# Altium schematic sheet SWITCHING.SchDoc
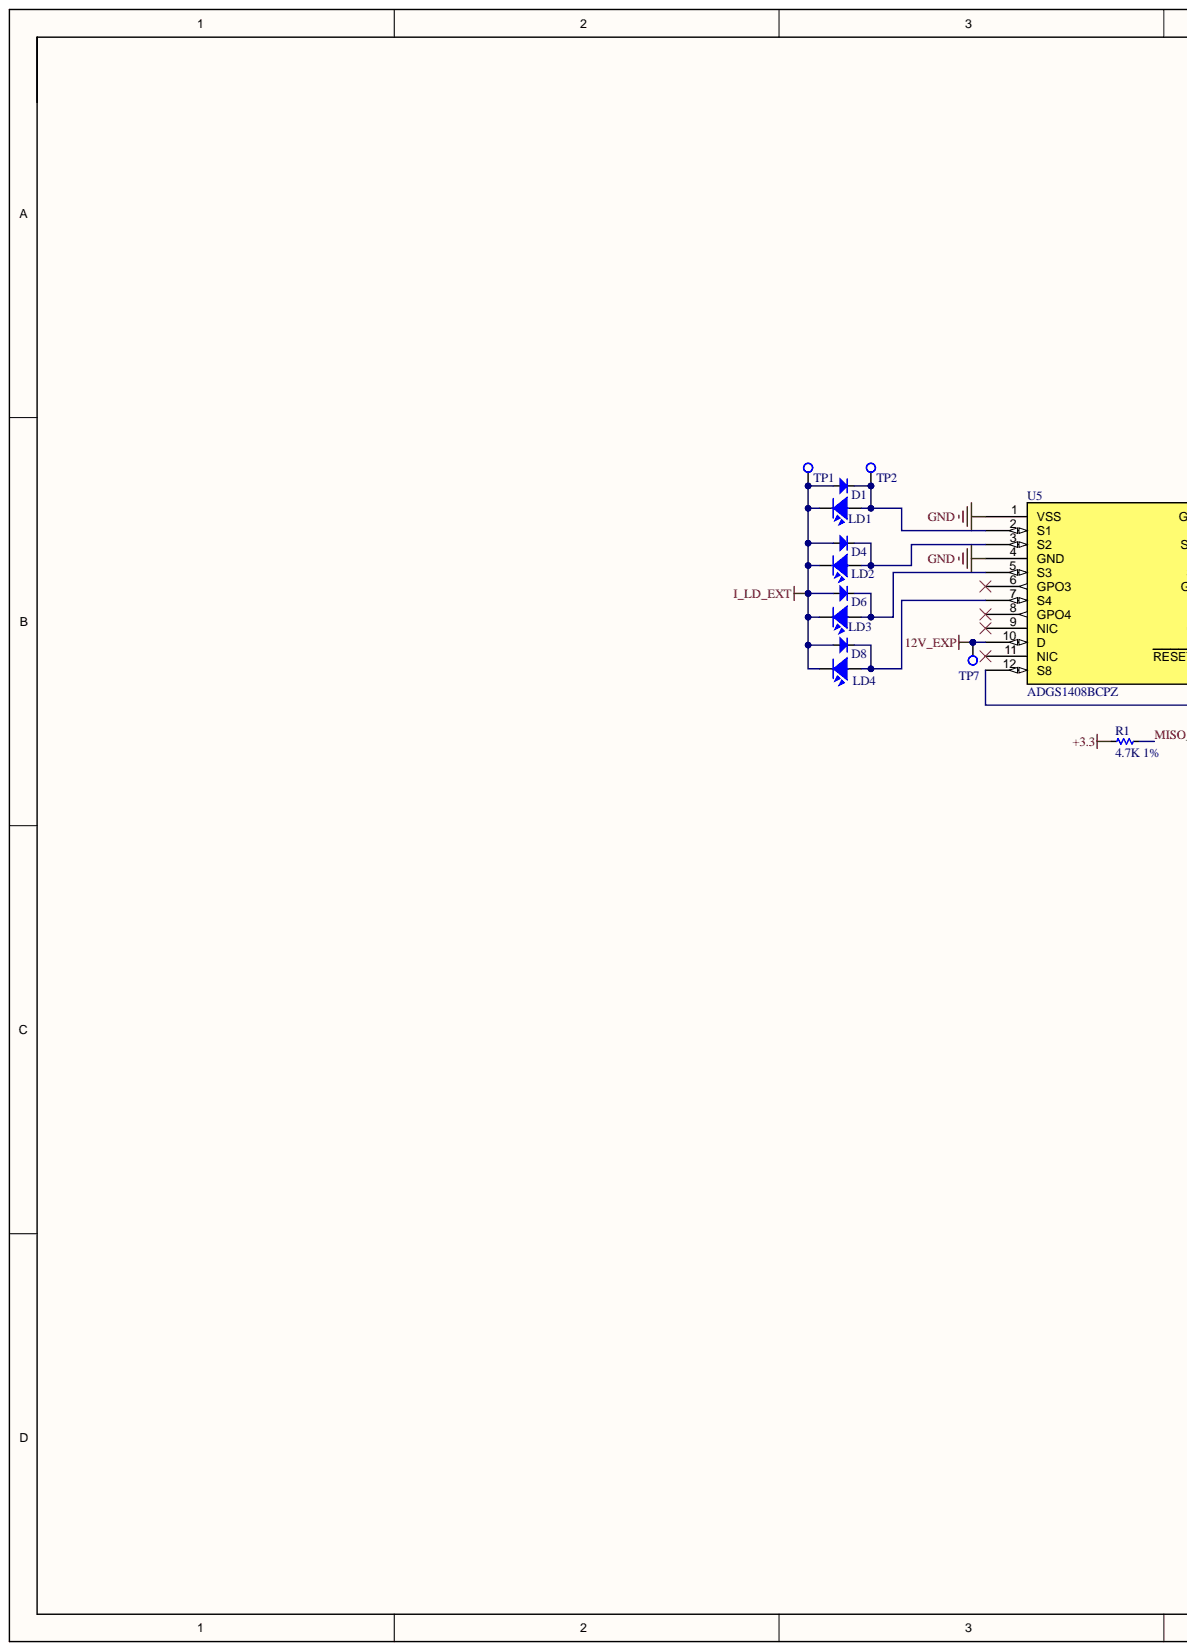
[diagram p0: SWITCHING.SchDoc, left]
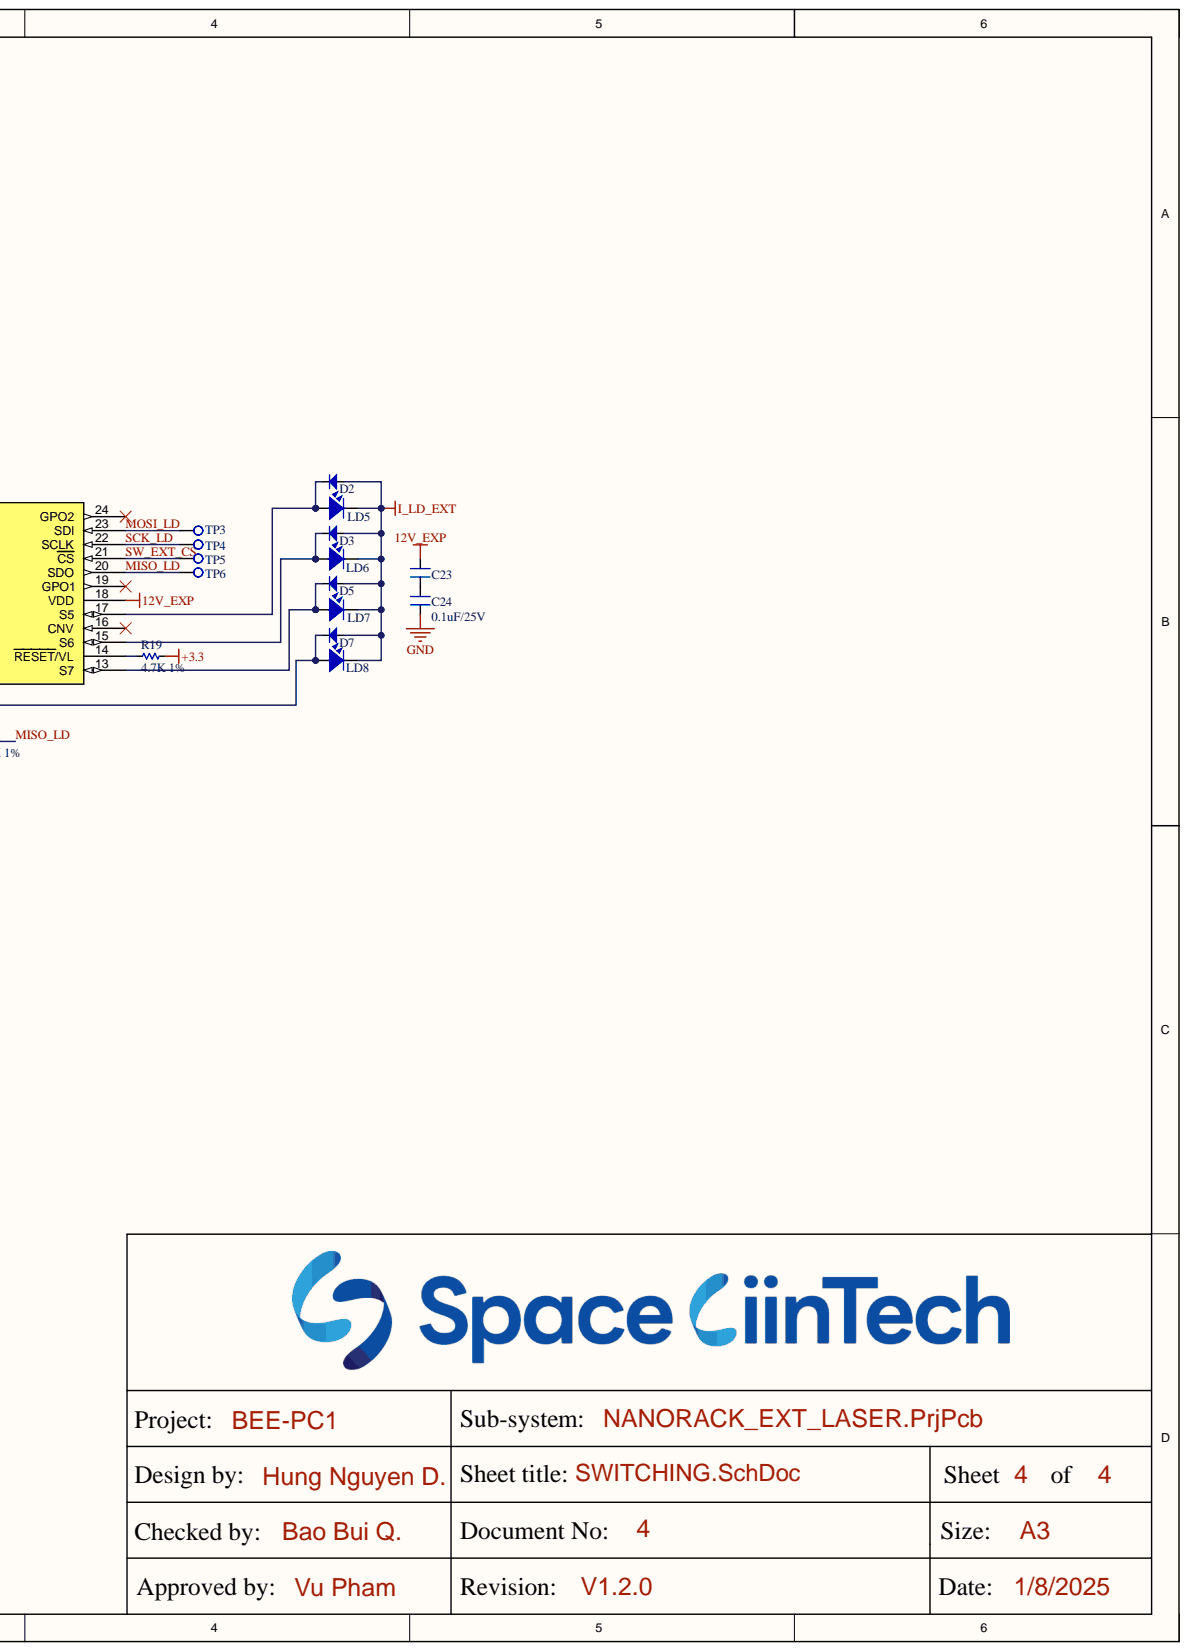
[diagram p1: SWITCHING.SchDoc, right]

## Parts
C23  0.1uF/25V  [SM-0603]
C24  0.1uF/25V  [SM-0603]
D1  1N4148W-TP  [SOD23]  (value not drawn)
D2  1N4148W-TP  [SOD23]  (value not drawn)
D3  1N4148W-TP  [SOD23]  (value not drawn)
D4  1N4148W-TP  [SOD23]  (value not drawn)
D5  1N4148W-TP  [SOD23]  (value not drawn)
D6  1N4148W-TP  [SOD23]  (value not drawn)
D7  1N4148W-TP  [SOD23]  (value not drawn)
D8  1N4148W-TP  [SOD23]  (value not drawn)
LD1  LaserDiode  [LASER]  (value not drawn)
LD2  LaserDiode  [LASER]  (value not drawn)
LD3  LaserDiode  [LASER]  (value not drawn)
LD4  LaserDiode  [LASER]  (value not drawn)
LD5  LaserDiode  [LASER]  (value not drawn)
LD6  LaserDiode  [LASER]  (value not drawn)
LD7  LaserDiode  [LASER]  (value not drawn)
LD8  LaserDiode  [LASER]  (value not drawn)
R1  4.7K 1%  [RES-SMD-0603]
R19  4.7K 1%  [RES-SMD-0603]
TP1  Test_Point  [TESTPOINT]  (value not drawn)
TP2  Test_Point  [TESTPOINT]  (value not drawn)
TP3  Test_Point  [TESTPOINT]  (value not drawn)
TP4  Test_Point  [TESTPOINT]  (value not drawn)
TP5  Test_Point  [TESTPOINT]  (value not drawn)
TP6  Test_Point  [TESTPOINT]  (value not drawn)
TP7  Test_Point  [TESTPOINT]  (value not drawn)
U5  ADGS1408BCPZ  [ADGS1408BCPZ]

## Nets
12V_EXP: C23.2 TP7.1 U5.10(D) U5.18(VDD)
+3.3: R1.2 R19.2
GND: C24.1 U5.1(VSS) U5.4(GND)
I_LD_EXT: D1.1 D2.1 D3.1 D4.1 D5.1 D6.1 D7.1 D8.1 LD1.3 LD2.3 LD3.3 LD4.3 LD5.3 LD6.3 LD7.3 LD8.3 TP1.1
MISO_LD: R1.1 TP6.1 U5.20(SDO)
MOSI_LD: TP3.1 U5.23(SDI)
SCK_LD: TP4.1 U5.22(SCLK)
SW_EXT_CS: TP5.1 U5.21(CS)
NetC23_1: C23.1 C24.2
NetD1_2: D1.2 LD1.1 TP2.1 U5.2(S1)
NetD2_2: D2.2 LD5.1 U5.17(S5)
NetD3_2: D3.2 LD6.1 U5.15(S6)
NetD4_2: D4.2 LD2.1 U5.3(S2)
NetD5_2: D5.2 LD7.1 U5.13(S7)
NetD6_2: D6.2 LD3.1 U5.5(S3)
NetD7_2: D7.2 LD8.1 U5.12(S8)
NetD8_2: D8.2 LD4.1 U5.7(S4)
NetR19_1: R19.1 U5.14(RESET/VL)

Not connected: U5 6(GPO3) 8(GPO4) 9(NIC) 11(NIC) 16(CNV) 19(GPO1) 24(GPO2)

## Library data of the parts
C23 C24 = CM: 0603; Value: 103; Component Kind: Standard; Library Name: Capacitors.SchLib
D1-D8 = Diode SMD: DIODE GEN PURP 100V 150MA SOD123; Code - JEDEC: DO-214-AB; Value: 1N5007; Component Kind: Standard; Library Name: Library.SchLib; Published: 8-Jun-2000; Revision: July-2002: Re-released for DXP Platform.; Publisher: Altium Limited
LD1-LD8 = LD: Component Kind: Standard; Library Name: Library.SchLib
R1 R19 = Res-SMD: 0603; Manufacturer: Generic Components; Class: Passive; Component Kind: Standard; Library Name: Library.SchLib; Published: 08/06/2000; Publisher: Altium Hobart Technology Centre
U5 = ADGS1408: IC MUX 8:1 4.7OHM 24LFCSP; Component Kind: Standard; Library Name: Library.SchLib
TP1-TP7 = Test_Point: Component Kind: Standard; Library Name: Library_HUNG.SchLib
